# Altium schematic sheet DBoard_conn.SchDoc
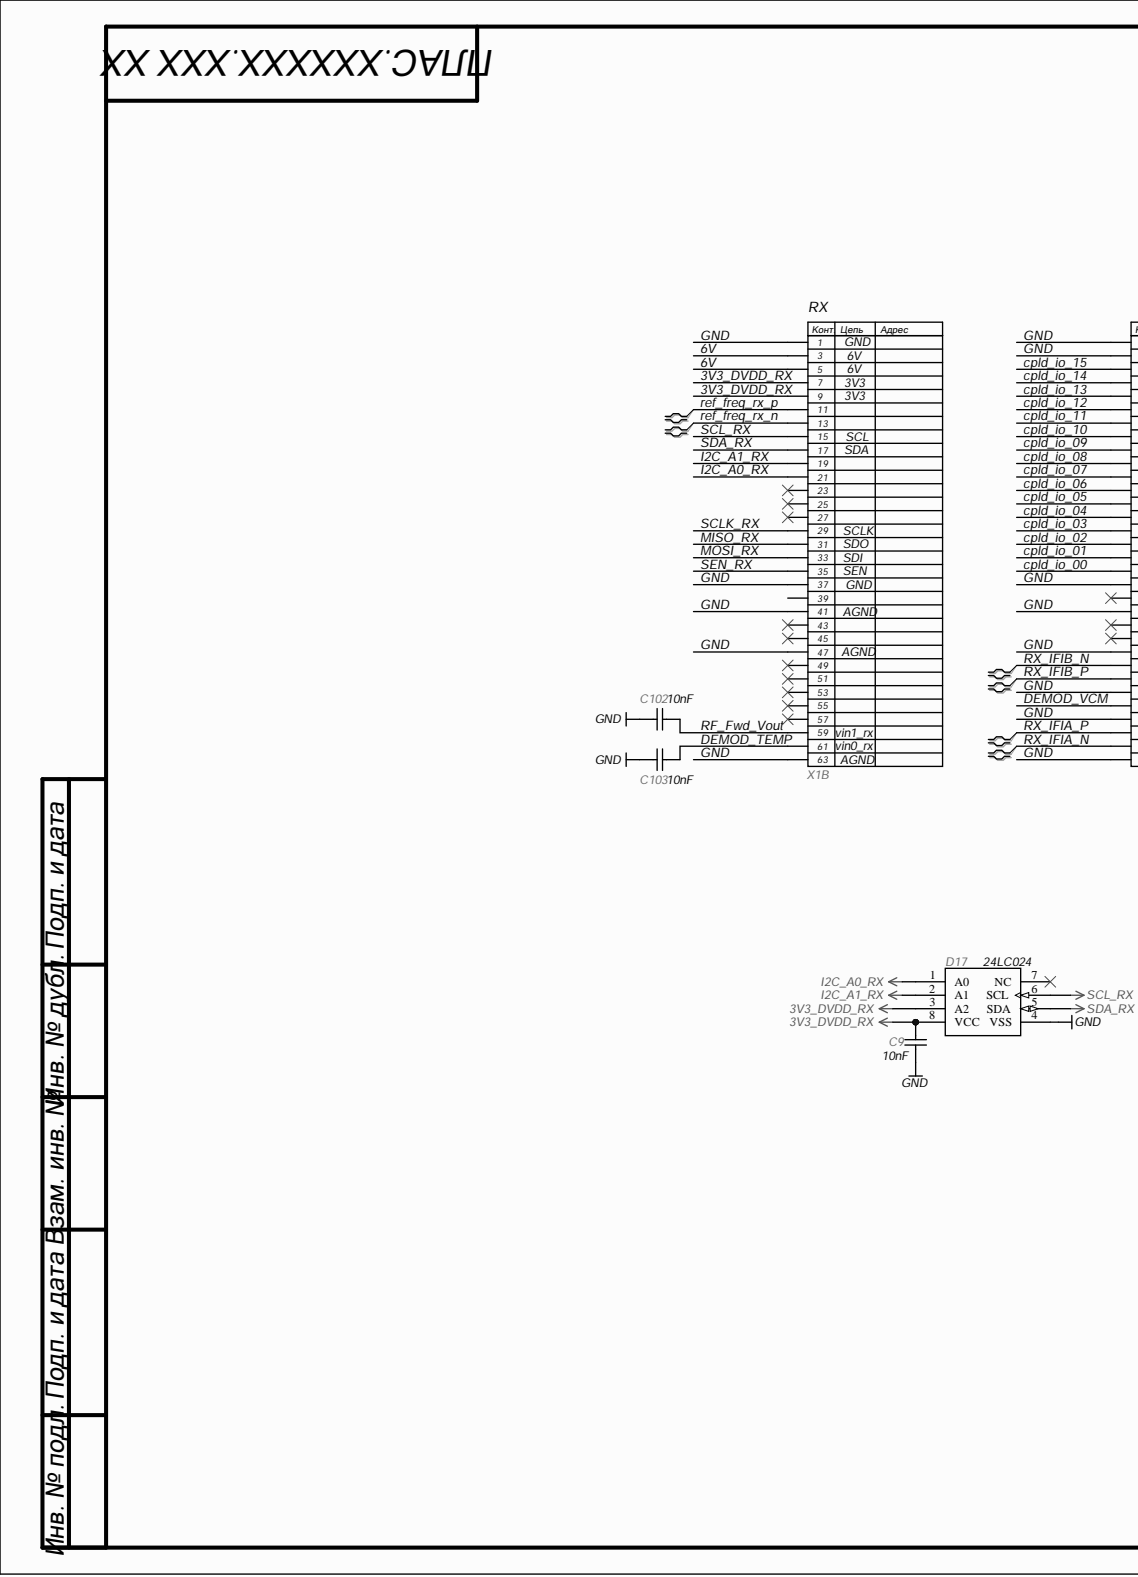
[diagram p0: DBoard_conn.SchDoc, left]
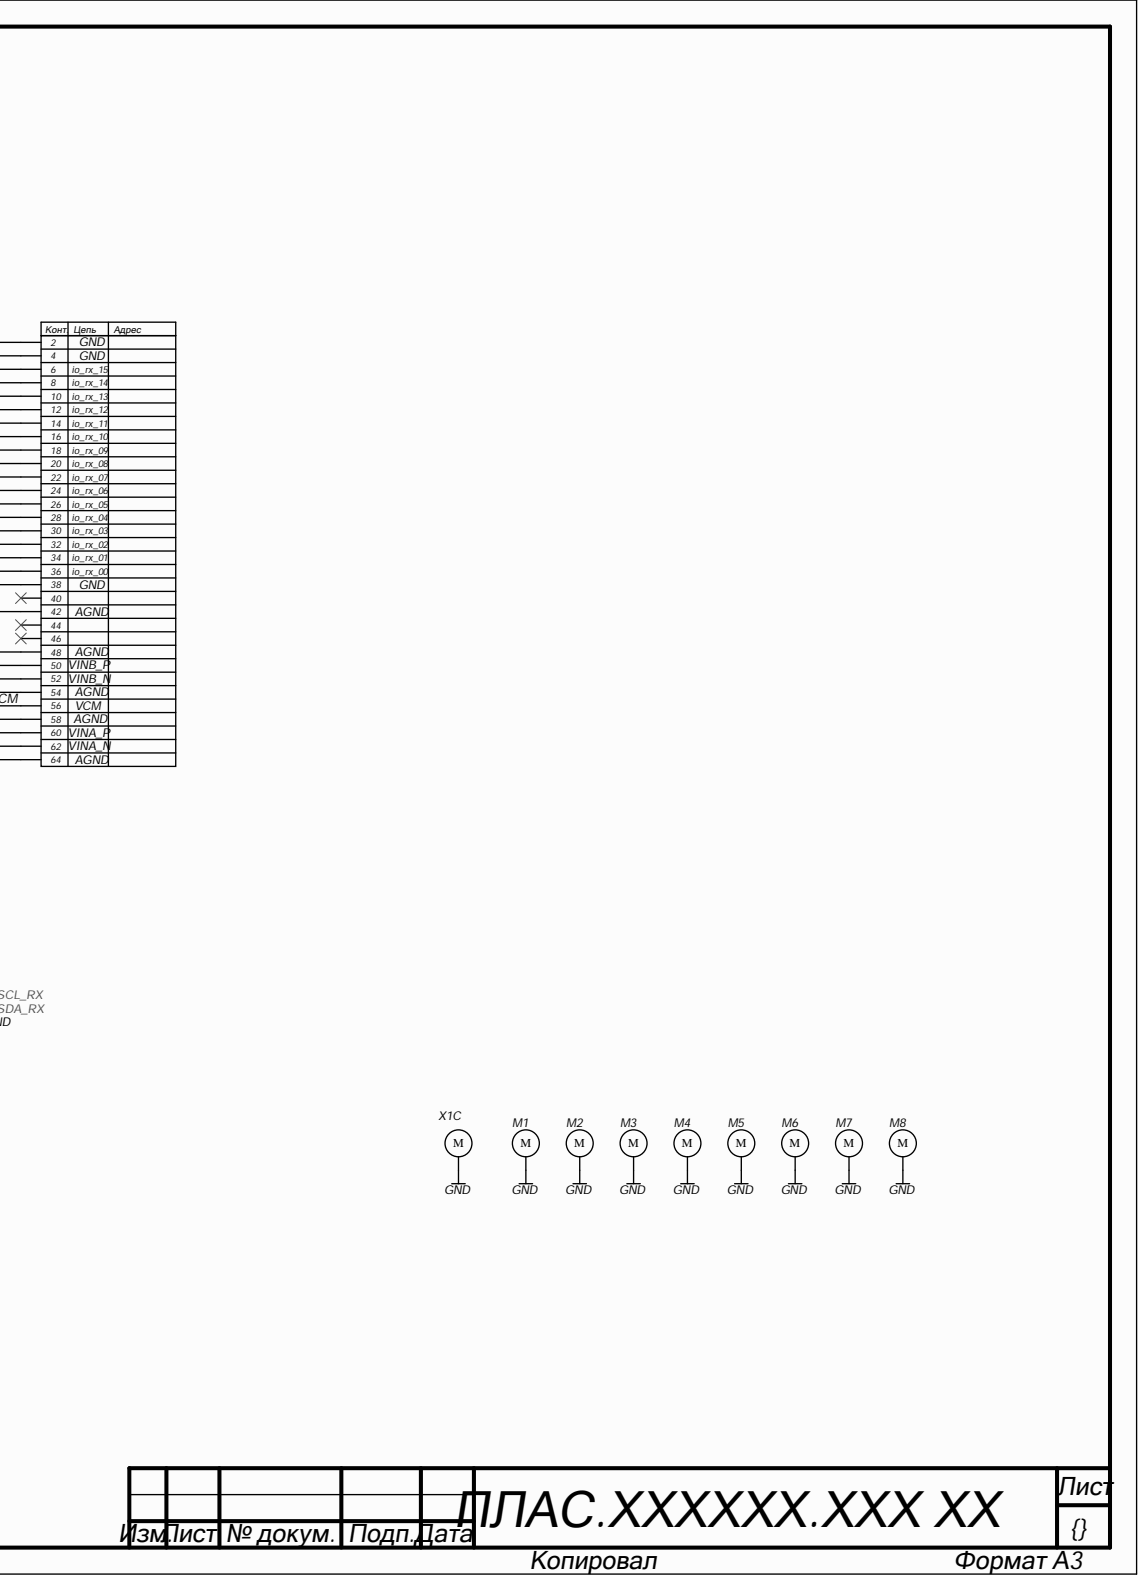
[diagram p1: DBoard_conn.SchDoc, right]

## Parts
C9  value 10nF  [C0402]  (hidden comment: CAP)
C102  value 10nF  [C0402]  (hidden comment: CAP)
C103  value 10nF  [C0402]  (hidden comment: CAP)
D17  24LC024  [SOIC_SN8]
M1  Via  [Mechanical_Via_2.6x4.2]  (value not drawn)
M2  Via  [Mechanical_Via_2.6x4.2]  (value not drawn)
M3  Via  [Mechanical_Via_2.6x4.2]  (value not drawn)
M4  Via  [Mechanical_Via_2.6x4.2]  (value not drawn)
M5  Via  [Mechanical_Via_2.6x4.2]  (value not drawn)
M6  Via  [Mechanical_Via_2.6x4.2]  (value not drawn)
M7  Via  [Mechanical_Via_2.6x4.2]  (value not drawn)
M8  Via  [Mechanical_Via_2.6x4.2]  (value not drawn)
X1  DBoard  [DBoard - half - MB]  (value not drawn)  (parts B,C)

## Nets
3V3_DVDD_RX: C9.2 D17.3(A2) D17.8(VCC) X1.71 X1.73
6V: X1.67 X1.69
cpld_io_00: X1.100
cpld_io_01: X1.98(cpld_io_01)
cpld_io_02: X1.96
cpld_io_03: X1.94
cpld_io_04: X1.92
cpld_io_05: X1.90
cpld_io_06: X1.88(cpld_io_06)
cpld_io_07: X1.86
cpld_io_08: X1.84
cpld_io_09: X1.82
cpld_io_10: X1.80
cpld_io_11: X1.78(cpld_io_11)
cpld_io_12: X1.76
cpld_io_13: X1.74
cpld_io_14: X1.72
cpld_io_15: X1.70
DEMOD_TEMP: C103.2 X1.125
DEMOD_VCM: X1.120
GND: C9.1 C102.1 C103.1 D17.4(VSS) M1.0 M2.0 M3.0 M4.0 M5.0 M6.0 M7.0 M8.0 X1.0(M) X1.65 X1.66 X1.68 X1.101 X1.102 X1.105 X1.106 X1.111 X1.112 X1.118 X1.122 X1.127 X1.128
I2C_A0_RX: D17.1(A0) X1.85
I2C_A1_RX: D17.2(A1) X1.83
MISO_RX: X1.95
MOSI_RX: X1.97
ref_freq_rx_n: X1.77
ref_freq_rx_p: X1.75
RF_Fwd_Vout: C102.2 X1.123
RX_IFIA_N: X1.126
RX_IFIA_P: X1.124
RX_IFIB_N: X1.114
RX_IFIB_P: X1.116
SCL_RX: D17.6(SCL) X1.79
SCLK_RX: X1.93
SDA_RX: D17.5(SDA) X1.81
SEN_RX: X1.99

Not connected: D17 7(NC); X1 87 89 91 103 104 107 108 109 110 113 115 117 119 121

## Library data of the parts
C9 C102 C103 = CAP: SMD Capacitor; Manufacturer: Murata; Material: X7R; P/N: GRM155R71E103KA01D; Tolerance: 10%; Type: Ceramic Capacitor; Voltage: 25V; Component Kind: Standard; Supplier 1: Digi-key; Supplier 2: Mouser; Supplier 3: Farnell; Supplier Part Number 1: 490-1312-1-ND; Supplier Part Number 2: 81-GRM36X103K25; Library Name: Kintex_SDR.SchLib
D17 = 24LC024: I2C EEPROM; Manufacturer: Microchip; Capacity: 2kbit; Max Clock: 400 kHz; P/N: 24LC024HT-I/SN; Voltage: 2.5-5.5V; Component Kind: Standard; Name: 24LC024; Package: SOIC-8; Supplier 1: Digi-key; Supplier 2: Mouser; Supplier 3: Farnell; Supplier Part Number 1: 24LC024HT-I/SNCT-ND; Supplier Part Number 2: 579-24LC024HT-I/SN; Type: I2C EEPROM; Value: 24LC024; Library Name: Kintex_SDR.SchLib
M1-M8 = Mechanical Via: Component Kind: Standard; Description: Mechanical Via; Supplier 4: Digi-key; Supplier 5: Mouser; Supplier 6: Farnell; Library Name: Kintex_SDR.SchLib
X1 = DBoard: USRP DBoard; Manufacturer: Molex; Name: 1.00mm Pitch Mezzanine 714390164; P/N: 714390164; Value: Molex 714390164; Component Kind: Standard; Library Name: DBLib.SchLib; Supplier 1: Digi-key; Supplier 2: Mouser; Supplier 3: Farnell; Supplier Part Number 1: WM17201-ND; Supplier Part Number 2: 538-71439-0164
